# Altium schematic sheet Tetris_main.SchDoc(Tetris_main)
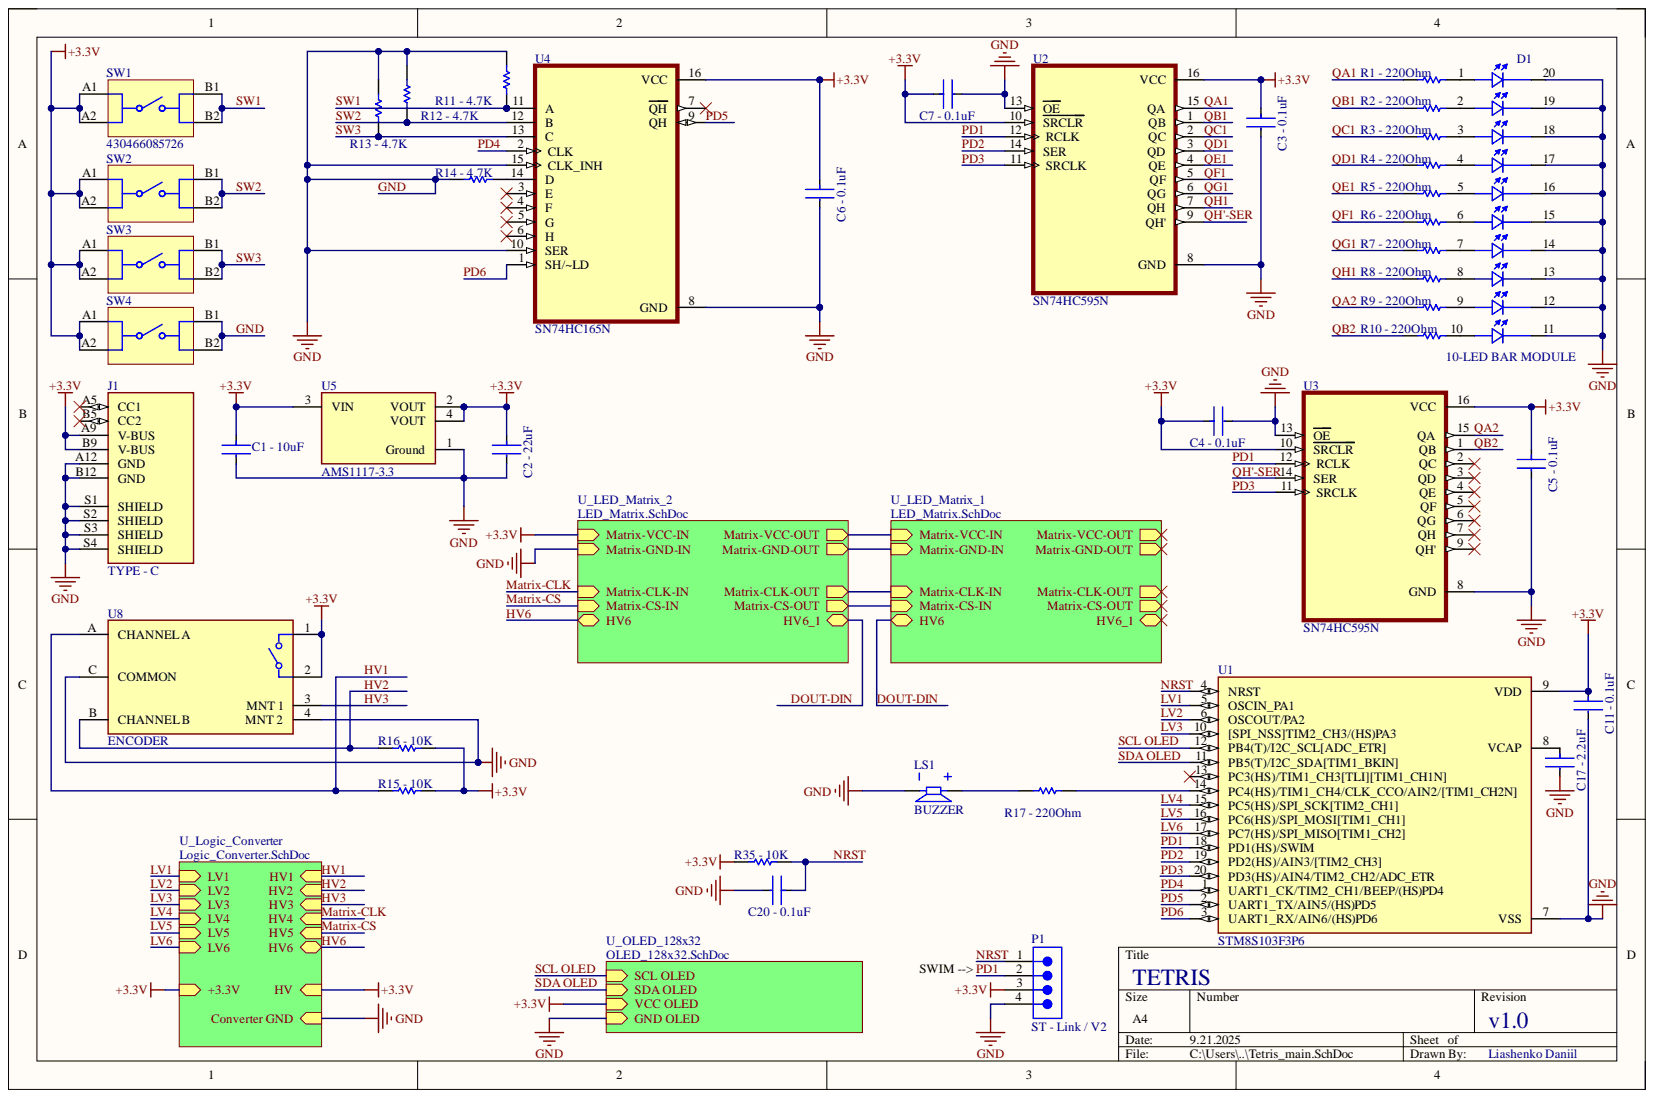
[diagram p0: Tetris_main.SchDoc]

## Parts
C1_-_10uF  GRM188R60J106ME47D  [FP-GRM188-0_1-e0_2_0_55-IPC_A]  (value not drawn)
C2_-_22uF  Capacitance: 22uF  [FP-CC0603-DC-MFG]  (hidden comment: CC0603MRX5R5BB226)
C3_-_0.1uF  CC0603KRX7R7BB104  [FP-CC0603-DA-MFG]  (value not drawn)
C4_-_0.1uF  CC0603KRX7R7BB104  [FP-CC0603-DA-MFG]  (value not drawn)
C5_-_0.1uF  CC0603KRX7R7BB104  [FP-CC0603-DA-MFG]  (value not drawn)
C6_-_0.1uF  CC0603KRX7R7BB104  [FP-CC0603-DA-MFG]  (value not drawn)
C7_-_0.1uF  CC0603KRX7R7BB104  [FP-CC0603-DA-MFG]  (value not drawn)
C11_-_0.1uF  CC0603KRX7R7BB104  [FP-CC0603-DA-MFG]  (value not drawn)
C17_-_2.2uF  CC0805KKX7R7BB105  [FP-CC0805-0_85-MFG]  (value not drawn)
C20_-_0.1uF  CC0603KRX7R7BB104  [FP-CC0603-DA-MFG]  (value not drawn)
D1  10-LED BAR MODULE  [DIP750W50P254L2540H825Q20]
J1  TYPE - C  [CUI_UJC-HP-3-SMT-TR]
LS1  BUZZER  [XDCR_CPI-148-24-90T-67]
P1  ST - Link / V2  [61300411021]
R1_-_220Ohm  RC0402FR-13220RL  [RESC1005X40X25ML05T10]  (value not drawn)
R2_-_220Ohm  RC0402FR-13220RL  [RESC1005X40X25ML05T10]  (value not drawn)
R3_-_220Ohm  RC0402FR-13220RL  [RESC1005X40X25ML05T10]  (value not drawn)
R4_-_220Ohm  RC0402FR-13220RL  [RESC1005X40X25ML05T10]  (value not drawn)
R5_-_220Ohm  RC0402FR-13220RL  [RESC1005X40X25ML05T10]  (value not drawn)
R6_-_220Ohm  RC0402FR-13220RL  [RESC1005X40X25ML05T10]  (value not drawn)
R7_-_220Ohm  RC0402FR-13220RL  [RESC1005X40X25ML05T10]  (value not drawn)
R8_-_220Ohm  RC0402FR-13220RL  [RESC1005X40X25ML05T10]  (value not drawn)
R9_-_220Ohm  RC0402FR-13220RL  [RESC1005X40X25ML05T10]  (value not drawn)
R10_-_220Ohm  RC0402FR-13220RL  [RESC1005X40X25ML05T10]  (value not drawn)
R11_-_4.7K  RC0603JR-074K7L  [RESC1608X55X25ML10T15]  (value not drawn)
R12_-_4.7K  RC0603JR-074K7L  [RESC1608X55X25ML10T15]  (value not drawn)
R13_-_4.7K  RC0603JR-074K7L  [RESC1608X55X25ML10T15]  (value not drawn)
R14_-_4.7K  RC0603JR-074K7L  [RESC1608X55X25ML10T15]  (value not drawn)
R15_-_10K  RC0603JR-074K7L  [RESC1608X55X25ML10T15]  (value not drawn)
R16_-_10K  RC0603JR-074K7L  [RESC1608X55X25ML10T15]  (value not drawn)
R17_-_220Ohm  RC0402FR-13220RL  [RESC1005X40X25ML05T10]  (value not drawn)
R35_-_10K  RC0603JR-074K7L  [RESC1608X55X25ML10T15]  (value not drawn)
SW1  430466085726  [430466085726]
SW2  430466085726  [430466085726]
SW3  430466085726  [430466085726]
SW4  430466085726  [430466085726]
U1  STM8S103F3P6  [SOP65P640X120-20N]
U2  SN74HC595N  [DIP794W45P254L1969H508Q16]
U3  SN74HC595N  [DIP794W45P254L1969H508Q16]
U4  SN74HC165N  [DIP794W45P254L1969H508Q16]
U5  AMS1117-3.3  [FP-AMS1117-IPC_B]
U8  ENCODER  [FP-PEC11R-4115F-S0018-MFG]

## Nets
+3.3V: C2_-_22uF.1 C3_-_0.1uF.1 C4_-_0.1uF.1 C5_-_0.1uF.1 C6_-_0.1uF.1 C7_-_0.1uF.1 C11_-_0.1uF.1 P1.3 R35_-_10K.1 SW1.A1 SW1.A2 SW2.A1 SW2.A2 SW3.A1 SW3.A2 SW4.A1 SW4.A2 U1.9(VDD) U2.10(SRCLR) U2.16(VCC) U3.10(SRCLR) U3.16(VCC) U4.16(VCC) U5.2(VOUT) U5.4(VOUT)
+5V: C1_-_10uF.1 J1.A9(V-BUS) J1.B9(V-BUS) R15_-_10K.2 R16_-_10K.2 U5.3(VIN) U8.1 U8.2
HV1: R15_-_10K.1 U8.A(CHANNEL_A)
HV2: R16_-_10K.1 U8.B(CHANNEL_B)
HV3: U8.3(MNT_1)
LV1: U1.5(OSCIN_PA1)
LV2: U1.6(OSCOUT/PA2)
LV3: U1.10([SPI_NSS]TIM2_CH3/(HS)PA3)
LV4: U1.15(PC5(HS)/SPI_SCK[TIM2_CH1])
LV5: U1.16(PC6(HS)/SPI_MOSI[TIM1_CH1])
LV6: U1.17(PC7(HS)/SPI_MISO[TIM1_CH2])
NRST: C20_-_0.1uF.1 P1.1 R35_-_10K.2 U1.4(NRST)
PD1: P1.2 U1.18(PD1(HS)/SWIM) U2.12(RCLK) U3.12(RCLK)
PD2: U1.19(PD2(HS)/AIN3/[TIM2_CH3]) U2.14(SER)
PD3: U1.20(PD3(HS)/AIN4/TIM2_CH2/ADC_ETR) U2.11(SRCLK) U3.11(SRCLK)
PD4: U1.1(UART1_CK/TIM2_CH1/BEEP/(HS)PD4) U4.2(CLK)
PD5: U1.2(UART1_TX/AIN5/(HS)PD5) U4.9(QH)
PD6: U1.3(UART1_RX/AIN6/(HS)PD6) U4.1(SH/~LD)
QA1: R1_-_220Ohm.1 U2.15(QA)
QA2: R9_-_220Ohm.1 U3.15(QA)
QB1: R2_-_220Ohm.1 U2.1(QB)
QB2: R10_-_220Ohm.1 U3.1(QB)
QC1: R3_-_220Ohm.1 U2.2(QC)
QD1: R4_-_220Ohm.1 U2.3(QD)
QE1: R5_-_220Ohm.1 U2.4(QE)
QF1: R6_-_220Ohm.1 U2.5(QF)
QG1: R7_-_220Ohm.1 U2.6(QG)
QH1: R8_-_220Ohm.1 U2.7(QH)
QH'-SER: U2.9(QH') U3.14(SER)
SCL OLED: U1.12(PB4(T)/I2C_SCL[ADC_ETR])
SDA OLED: U1.11(PB5(T)/I2C_SDA[TIM1_BKIN])
SW1: R11_-_4.7K.1 SW1.B1 SW1.B2 U4.11(A)
SW2: R12_-_4.7K.1 SW2.B1 SW2.B2 U4.12(B)
SW3: R13_-_4.7K.1 SW3.B1 SW3.B2 U4.13(C)
SW4: C1_-_10uF.2 C2_-_22uF.2 C3_-_0.1uF.2 C4_-_0.1uF.2 C5_-_0.1uF.2 C6_-_0.1uF.2 C7_-_0.1uF.2 C11_-_0.1uF.2 C17_-_2.2uF.2 C20_-_0.1uF.2 D1.11 D1.12 D1.13 D1.14 D1.15 D1.16 D1.17 D1.18 D1.19 D1.20 J1.A12(GND) J1.B12(GND) J1.S1(SHIELD) J1.S2(SHIELD) J1.S3(SHIELD) J1.S4(SHIELD) LS1.N P1.4 R11_-_4.7K.2 R12_-_4.7K.2 R13_-_4.7K.2 R14_-_4.7K.1 SW4.B1 SW4.B2 U1.7(VSS) U2.8(GND) U2.13(OE) U3.8(GND) U3.13(OE) U4.8(GND) U4.10(SER) U4.15(CLK_INH) U5.1(Ground) U8.4(MNT_2) U8.C(COMMON)
NetC17 - 2.2uF_1: C17_-_2.2uF.1 U1.8(VCAP)
NetD1_1: D1.1 R1_-_220Ohm.2
NetD1_2: D1.2 R2_-_220Ohm.2
NetD1_3: D1.3 R3_-_220Ohm.2
NetD1_4: D1.4 R4_-_220Ohm.2
NetD1_5: D1.5 R5_-_220Ohm.2
NetD1_6: D1.6 R6_-_220Ohm.2
NetD1_7: D1.7 R7_-_220Ohm.2
NetD1_8: D1.8 R8_-_220Ohm.2
NetD1_9: D1.9 R9_-_220Ohm.2
NetD1_10: D1.10 R10_-_220Ohm.2
NetJ1_A5: J1.A5(CC1)
NetJ1_B5: J1.B5(CC2)
NetLS1_P: LS1.P R17_-_220Ohm.1
NetR14 - 4.7K_2: R14_-_4.7K.2 U4.14(D)
NetR17 - 220Ohm_2: R17_-_220Ohm.2 U1.14(PC4(HS)/TIM1_CH4/CLK_CCO/AIN2/[TIM1_CH2N])
NetU1_13: U1.13(PC3(HS)/TIM1_CH3[TLI][TIM1_CH1N])
NetU3_2: U3.2(QC)
NetU3_3: U3.3(QD)
NetU3_4: U3.4(QE)
NetU3_5: U3.5(QF)
NetU3_6: U3.6(QG)
NetU3_7: U3.7(QH)
NetU3_9: U3.9(QH')
NetU4_3: U4.3(E)
NetU4_4: U4.4(F)
NetU4_5: U4.5(G)
NetU4_6: U4.6(H)
NetU4_7: U4.7(QH)
HV6:
HV6_1:
Matrix-CLK:
Matrix-CLK-IN:
Matrix-CLK-OUT:
Matrix-CS:
Matrix-CS-IN:
Matrix-CS-OUT:
Matrix-GND-IN:
Matrix-GND-OUT:
Matrix-VCC-IN:
Matrix-VCC-OUT:

## Library data of the parts
C1_-_10uF = CMP-2006-02792-2: Chip Multilayer Ceramic Capacitors for General Purpose, 0603, 10uF, X5R, 15%, 20%, 6.3V; Capacitance: 10uF; Case Code (Imperial): 0603; Case Code (Metric): 1608; Case/Package: 0603; Component Kind: Standard; Depth: 0.8mm; Dielectric: X5R; Height: 0.8mm; Lead Free: Lead Free; Length: 1.6mm; Library Name: Altium Content Vault; Material: Ceramic; Max Operating Temperature: 85°C; Min Operating Temperature: -55°C; Mount: Surface Mount; Package Quantity: 4000; Packaging: Tape and Reel; Radiation Hardening: No; REACH SVHC: No SVHC; Termination: SMD/SMT; Thickness: 0.035inch; Tolerance: 20%; Voltage: 6.3V; Voltage Rating: 6.3V; Voltage Rating (DC): 6.3V; Width: 0.031inch
C2_-_22uF = CMP-2000-06075-2: CAP CER 22UF 6.3V X5R 0603; Tolerance: 20%; Case Code (Imperial): 0603; Case Code (Metric): 1608; Case/Package: 0603; Component Kind: Standard; Depth: 0.8mm; Dielectric: X5R; Halogen Free: Halogen Free; Lead Free: Lead Free; Length: 0.063inch; Library Name: Altium Content Vault; Max Operating Temperature: 85°C; Min Operating Temperature: -55°C; Mount: Surface Mount; Package Quantity: 4000; Packaging: Tape and Reel; Resistor Type: Ceramic; RoHS Compliant: Yes; Termination: SMD/SMT; Thickness: 0.035inch; Voltage Rating: 6.3V; Voltage Rating (DC): 6.3V; Weight: 7.1E-05oz; Width: 0.031inch
C3_-_0.1uF C4_-_0.1uF C5_-_0.1uF C6_-_0.1uF C7_-_0.1uF C11_-_0.1uF C20_-_0.1uF = CMP-2000-05002-2: CAP CER 0.1UF 16V X7R 0603; Manufacturer: YAGEO; Capacitance: 100 nF; Material: Ceramic; Temperature Coefficient: 15%; Tolerance: 0.1; Value: 100 nF; Automotive: No; Category: Cap; Component Kind: Standard; ComponentLink2Description: Datasheet; ComponentLink2URL: http://www.yageo.com/documents/recent/UPY-GPHC_X7R_6.3V-to-50V_18.pdf; Device Class L1: Passive Components; Device Class L2: Capacitors; Device Class L3: Ceramic Capacitors; Digikey Description: CAP CER 0.1UF 16V X7R 0603; Footprint Url: http://www.yageo.com/exep/pages/download/literatures/UPY-C_GEN_24.pdf; Height: 0.9mm; Lead Free: Yes; Library Name: Altium Content Vault; Manufacturer Part Number: CC0603KRX7R7BB104; Mouser Description: Multilayer Ceramic Capacitor, CC Series, 0.1 - F, - 10%, X7R, 16 V, 0603 [1608 Metric]; Octopart: https://octopart.com/cc0603krx7r7bb104-yageo-192941; Package: CC0603-DA; RoHS: TRUE; Temperature: -55°C to +125°C; Temperature Characteristic: X7R; Temperature Range High: +125°C; Temperature Range Low: -55°C; Voltage: 16 V; Voltage Rating: 16 V
C17_-_2.2uF = CMP-03422-000001-1: CAP CER 1UF 16V X7R 0805; Manufacturer: Yageo; Material: Ceramic; Tolerance: 0.1; Automotive: No; Capacitance: 1 uF; Category: Cap; Component Kind: Standard; ComponentLink2Description: Datasheet; ComponentLink2URL: http://www.yageo.com/documents/recent/UPY-GPHC_Y5V_6.3V-to-50V_8.pdf; Device Class L1: Passive Components; Device Class L2: Capacitors; Device Class L3: Ceramic Capacitors; Digikey Description: CAP CER 1UF 16V X7R 0805; Footprint Url: http://www.yageo.com/exep/pages/download/literatures/UPY-C_GEN_24.pdf; Height: 0.95mm; Ipc Land Pattern Name: CAPC200125X85; Lead Free: Yes; Library Name: Altium Content Vault; Manufacturer Part Number: CC0805KKX7R7BB105; Mouser Description: Multilayer Ceramic Capacitors MLCC - SMD/SMT 1.0uF 16V X7R 10%; Octopart: https://octopart.com/cc0805kkx7r7bb105-yageo-8376555; Package: CC0805-0.85; RoHS: TRUE; Temperature: -30°C to +85°C; Temperature Characteristic: X7R; Temperature Range High: +85°C; Temperature Range Low: -30°C; Value: 1 uF; Voltage Rating: 16 V
D1 = CMP-004-00031-1: LED Circuit Board Indicator Bar - 10 Wide, DIP Red (x 10) Diffused, White 2V 30mA Through Hole; MF: Kingbright; MP: DC10EWA; Availability: In Stock; Case/Package: DIP-20 Kingbright; Check_prices: https://www.snapeda.com/parts/DC10EWA/Kingbright/view-part/268697/?ref=eda; Component Kind: Standard; Component Type: Standard; Design Item ID: DC10EWA; Pins: 20; Price: None; Purchase-URL: https://pricing.snapeda.com/search/part/DC10EWA/?ref=eda; SnapEDA_Link: https://www.snapeda.com/parts/DC10EWA/Kingbright/view-part/268697/?ref=snap; Source: DC10EWA.SchLib; Library Name: National Technical University of Ukraine Igor Sikorsky Kyiv Polytechnic Institute- Educator Program
J1 = CMP-039-000000-1: MP: UJC-HP-3-SMT-TR; Availability: Unavailable; Component Kind: Standard; Component Type: Standard; Design Item ID: UJC-HP-3-SMT-TR; Package: None; Pin Count: 10; Price: None; Source: UJC-HP-3-SMT-TR.SchLib; Library Name: National Technical University of Ukraine Igor Sikorsky Kyiv Polytechnic Institute- Educator Program
LS1 = CMP-037-000000-1: 13,9 РјРј, 24 Р’ РїРѕСЃС‚. С‚РѕРєР°, 90 РґР‘, СЃРєРІРѕР·РЅРѕРµ РѕС‚РІРµСЂСЃС‚РёРµ, РїСЊРµР·РѕСЌР»РµРєС‚СЂРёС‡РµСЃРєРёР№ Р·РІСѓРєРѕРІРѕР№ РёРЅРґРёРєР°С‚РѕСЂ; MF: Same Sky; MP: CPI-148-24-90T-67; Check_prices: https://www.snapeda.com/parts/CPI-148-24-90T-67/Same+Sky/view-part/?ref=eda; Component Kind: Standard; Component Type: Standard; Design Item ID: CPI-148-24-90T-67; Package: None; Pin Count: 2; SnapEDA_Link: https://www.snapeda.com/parts/CPI-148-24-90T-67/Same+Sky/view-part/?ref=snap; Source: CPI-148-24-90T-67.SchLib; Library Name: National Technical University of Ukraine Igor Sikorsky Kyiv Polytechnic Institute- Educator Program
P1 = CMP-043-000000-1: NexarPartId: 31153320; Connector Type: Male Pin; Contact Resistance: 20m?; Current Rating: 3A; Gender: Male; Number of Contacts: 4; Operating Supply Voltage: 250V; Pitch: 2.54mm; Color: Black; Component Kind: Standard; Component Type: Standard; Contact Material: Copper; Contact Mating Length: 5.9944mm; Contact Plating: Gold; Design Item ID: CMP-1502-01063-3; Lead Pitch: 2.54mm; Mount: Through Hole; Number of Pins: 4; Number of Positions: 4; Number of Rows: 1; Pin Count: 4; REACH SVHC: Unknown; RoHS: Compliant; Source: Components - 25.08.25 - 11.48.SchLib; Termination: Solder; Library Name: National Technical University of Ukraine Igor Sikorsky Kyiv Polytechnic Institute- Educator Program
R1_-_220Ohm R2_-_220Ohm R3_-_220Ohm R4_-_220Ohm R5_-_220Ohm R6_-_220Ohm R7_-_220Ohm R8_-_220Ohm R9_-_220Ohm R10_-_220Ohm R17_-_220Ohm = CMP-2002-07866-1: Manufacturer: Yageo; Component Kind: Standard; Library Name: Altium Content Vault; Part Number: RC0402FR-13220RL
R11_-_4.7K R12_-_4.7K R13_-_4.7K R14_-_4.7K R15_-_10K R16_-_10K R35_-_10K = CMP-2000-05024-1: Chip Resistor, 4.7 KOhm, +/- 5%, 100 mW, -55 to 155 degC, 0603 (1608 Metric), RoHS, Tape and Reel; Manufacturer: Yageo; Power Rating: 100mW; Resistance: 4.7kOhms; Temperature Coefficient: 100PPM/C; Voltage Rating: 50V; Case Code - in: 0603; Case Code - mm: 1608; Component Kind: Standard; Datasheet URL: http://www.yageo.com/documents/recent/PYu-RC_Group_51_RoHS_L_04.pdf; Datasheet Version: Rev. 4, 02/2016; Library Name: Altium Content Vault; Manufacturer URL: http://www.yageo.com/; Operating Temperature Range: -55Cto+155C; Package Description: 2-Pin Surface Mount Device, Body 1.6 x 0.8 mm; Package Reference: 603; Packaging: Reel; Product: ThickFilmResistorsGeneralPurpose; Series: RC; Termination Style: SMD/SMT; Tolerance: 5%
SW1-SW4 = CMP-1456-00006-3: Switch Tactile Spst-no 0.05A 12V; Contact Current Rating: 50mA; Insulation Resistance: 100MΩ; Color: White; Component Kind: Standard; Contact Plating: Silver; Current Rating: 50mA; Height: 8.5mm; Library Name: Altium Content Vault; Max Operating Temperature: 85°C; Min Operating Temperature: -40°C; Packaging: Bulk; RoHS: Compliant; Voltage Rating: 12V
U1 = CMP-031-00026-1: STM8 series Microcontroller IC 8-Bit 16MHz 8KB (8K x 8) FLASH 20-TSSOP; MF: STMicroelectronics; Case/Package: TSSOP-20 STMicroelectronics; Check_prices: https://www.snapeda.com/parts/STM8S103F3P6/STMicroelectronics/view-part/?ref=eda; Component Kind: Standard; Component Type: Standard; Design Item ID: STM8S103F3P6; MP: STM8S103F3P6; Pins: 20; SnapEDA_Link: https://www.snapeda.com/parts/STM8S103F3P6/STMicroelectronics/view-part/?ref=snap; Source: STM8S103F3P6.SchLib; Library Name: National Technical University of Ukraine Igor Sikorsky Kyiv Polytechnic Institute- Educator Program
U2 U3 = CMP-029-000000-1: 8-bit shift registers with 3-state output registers; MF: Texas Instruments; Check_prices: https://www.snapeda.com/parts/SN74HC595N/Texas+Instruments/view-part/?ref=eda; Component Kind: Standard; Component Type: Standard; Design Item ID: SN74HC595N; MP: SN74HC595N; Package: PDIP-16 Texas Instruments; Pin Count: 16; SnapEDA_Link: https://www.snapeda.com/parts/SN74HC595N/Texas+Instruments/view-part/?ref=snap; Source: SN74HC595N.SchLib; Library Name: National Technical University of Ukraine Igor Sikorsky Kyiv Polytechnic Institute- Educator Program
U4 = CMP-031-000000-4: 8-Bit Parallel-Load Shift Registers; MF: Texas Instruments; Check_prices: https://www.snapeda.com/parts/SN74HC165N/Texas+Instruments/view-part/?ref=eda; Component Kind: Standard; Component Type: Standard; Design Item ID: SN74HC165N; MP: SN74HC165N; Package: PDIP-16 Texas Instruments; Pin Count: 16; SnapEDA_Link: https://www.snapeda.com/parts/SN74HC165N/Texas+Instruments/view-part/?ref=snap; Source: SN74HC165N.SchLib; Library Name: National Technical University of Ukraine Igor Sikorsky Kyiv Polytechnic Institute- Educator Program
U5 = CMP-012-00000-1: Fixed Positive LDO Regulator, 3.3V, 1.3V Dropout, PDSO3; Channels: 1; Output Current1-Max: 1A; Output Voltage1-Max: 3.399V; Output Voltage1-Min: 3.201V; Package Body Material: Plastic; Regulator Type: FIXED POSITIVE SINGLE OUTPUT LDO REGULATOR; Case/Package: SOP; Component Kind: Standard; Dropout Voltage1-Max: 1.3V; Height - Seated (Max): 1.8mm; JESD-30 Code: R-PDSO-G3; Length: 6.505mm; Operating Temperature, TJ-Max: 125°C; Operating Temperature, TJ-Min: -40°C; Output Voltage1-Nom: 3.3V; Package Shape: Rectangular; Package Style: SMALL OUTLINEMeter; Pins: 3; Reach SVHC Compliant: Yes; RoHS Compliant: Yes; Surface Mount: Yes; Terminal Form: Gull Wing; Terminal Pitch: 2.29mm; Terminal Position: DUAL; Width: 3.505mm; Library Name: National Technical University of Ukraine Igor Sikorsky Kyiv Polytechnic Institute- Educator Program
U8 = CMP-07421-000064-1: ROTARY ENCODER MECHANICAL 18PPR; Built in Switch: Yes; Hole Diameter: 1.8mm; Number of Channels: 2; Output Type: Quadrature; Pulses per Revolution: 18; Component Kind: Standard; Detent: Yes; Encoder Type: Mechanical; Height: 13.4mm; Length: 21.5mm; Library Name: Altium Content Vault; Max Operating Temperature: 70°C; Min Operating Temperature: -30°C; Mount: Through Hole; Number of Pins: 5; Orientation: Vertical; Package Quantity: 150; Packaging: Bulk; Radiation Hardening: No; REACH SVHC: No SVHC; RoHS Compliant: Yes; Series: PEC11R; Termination: PC Pins; Width: 12.5mm
